# Altium schematic sheet Sheet2.SchDoc
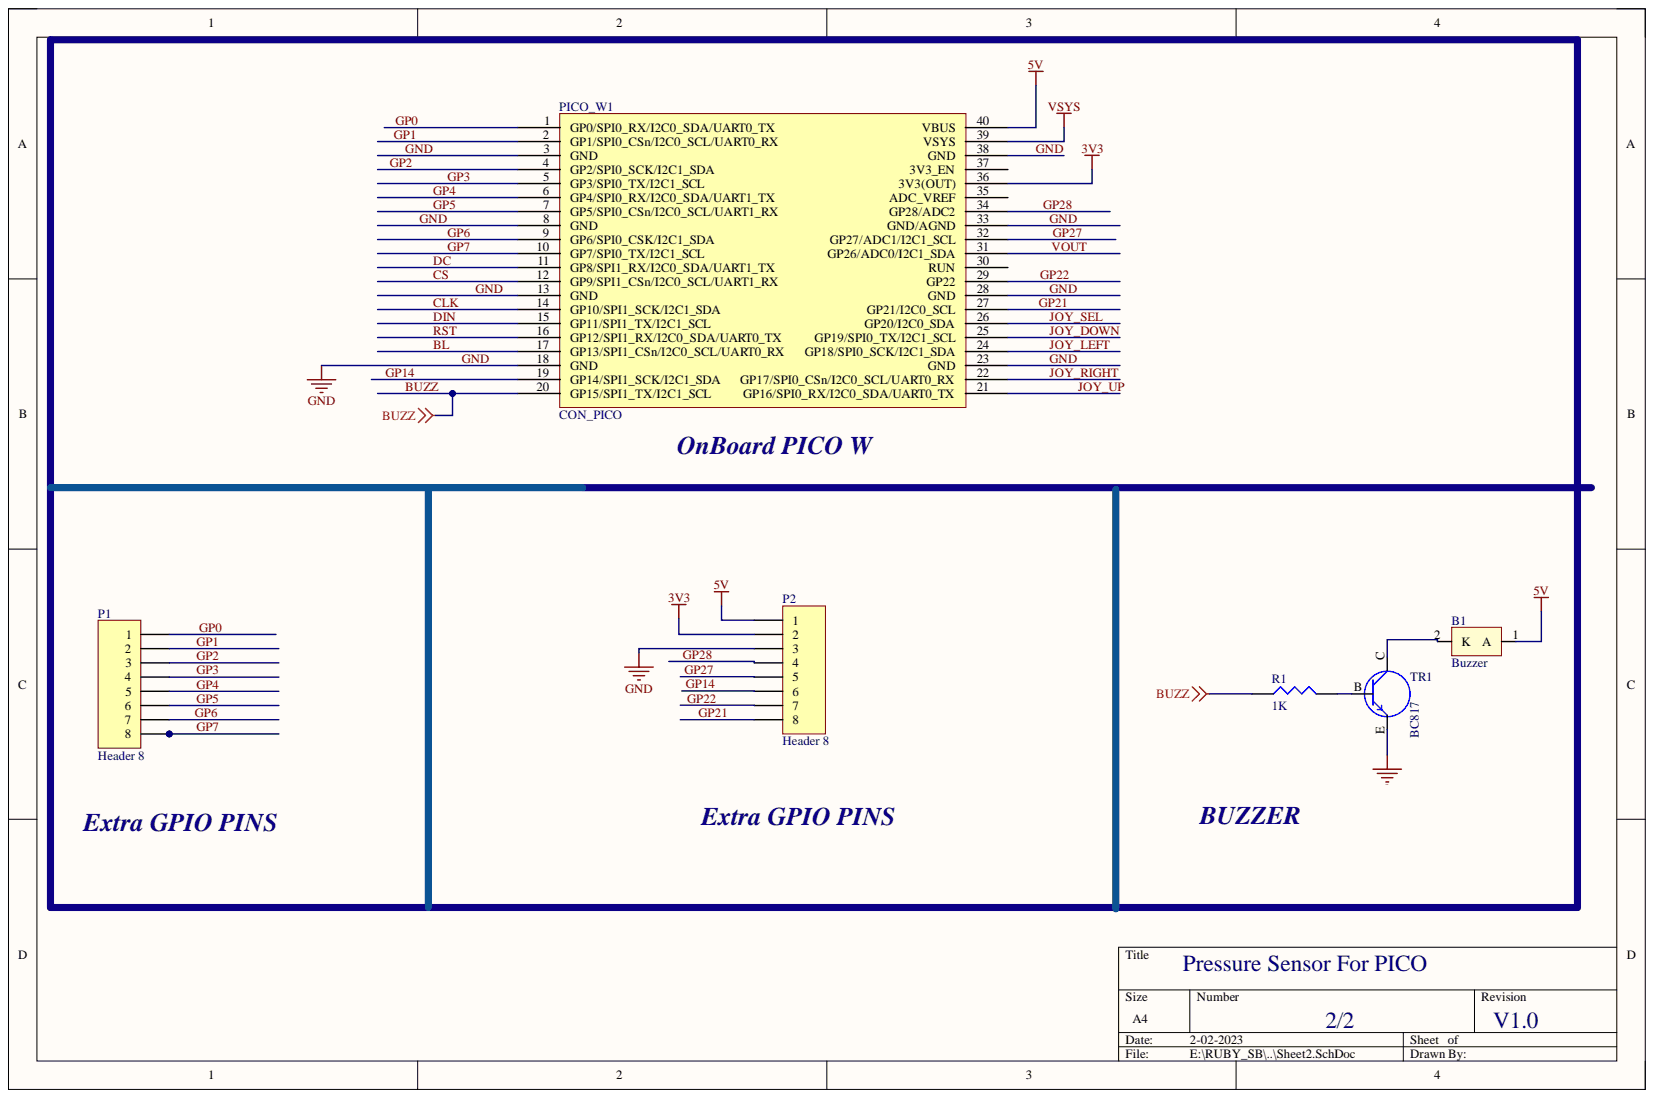
[diagram p0: Sheet2.SchDoc]

## Parts
B1  Buzzer  [Buzzer]
P1  Header 8  [8P_BERG_2.54MM]
P2  Header 8  [8P_BERG_2.54MM]
PICO_W1  CON_PICO  [PICO W]
R1  1K  [R_0603]
TR1  BC817  [SOT23]

## Nets
3V3: P2.2 PICO_W1.36(3V3(OUT))
5V: B1.1 P2.1 PICO_W1.40(VBUS)
VSYS: PICO_W1.39(VSYS)
BL: PICO_W1.17(GP13/SPI1_CSn/I2C0_SCL/UART0_RX)
BUZZ: PICO_W1.20(GP15/SPI1_TX/I2C1_SCL) R1.1
CLK: PICO_W1.14(GP10/SPI1_SCK/I2C1_SDA)
CS: PICO_W1.12(GP9/SPI1_CSn/I2C0_SCL/UART1_RX)
DC: PICO_W1.11(GP8/SPI1_RX/I2C0_SDA/UART1_TX)
DIN: PICO_W1.15(GP11/SPI1_TX/I2C1_SCL)
GND: P2.3 PICO_W1.3(GND) PICO_W1.8(GND) PICO_W1.13(GND) PICO_W1.18(GND) PICO_W1.23(GND) PICO_W1.28(GND) PICO_W1.33(GND/AGND) PICO_W1.38(GND) TR1.E
GP0: P1.1 PICO_W1.1(GP0/SPI0_RX/I2C0_SDA/UART0_TX)
GP1: P1.2 PICO_W1.2(GP1/SPI0_CSn/I2C0_SCL/UART0_RX)
GP2: P1.3 PICO_W1.4(GP2/SPI0_SCK/I2C1_SDA)
GP3: P1.4 PICO_W1.5(GP3/SPI0_TX/I2C1_SCL)
GP4: P1.5 PICO_W1.6(GP4/SPI0_RX/I2C0_SDA/UART1_TX)
GP5: P1.6 PICO_W1.7(GP5/SPI0_CSn/I2C0_SCL/UART1_RX)
GP6: P1.7 PICO_W1.9(GP6/SPI0_CSK/I2C1_SDA)
GP7: P1.8 PICO_W1.10(GP7/SPI0_TX/I2C1_SCL)
GP14: P2.6 PICO_W1.19(GP14/SPI1_SCK/I2C1_SDA)
GP21: P2.8 PICO_W1.27(GP21/I2C0_SCL)
GP22: P2.7 PICO_W1.29(GP22)
GP27: P2.5 PICO_W1.32(GP27/ADC1/I2C1_SCL)
GP28: P2.4 PICO_W1.34(GP28/ADC2)
JOY_DOWN: PICO_W1.25(GP19/SPI0_TX/I2C1_SCL)
JOY_LEFT: PICO_W1.24(GP18/SPI0_SCK/I2C1_SDA)
JOY_RIGHT: PICO_W1.22(GP17/SPI0_CSn/I2C0_SCL/UART0_RX)
JOY_SEL: PICO_W1.26(GP20/I2C0_SDA)
JOY_UP: PICO_W1.21(GP16/SPI0_RX/I2C0_SDA/UART0_TX)
RST: PICO_W1.16(GP12/SPI1_RX/I2C0_SDA/UART0_TX)
VOUT: PICO_W1.31(GP26/ADC0/I2C1_SDA)
NetB1_2: B1.2 TR1.C
NetR1_2: R1.2 TR1.B

Not connected: PICO_W1 30(RUN) 35(ADC_VREF) 37(3V3_EN)

## Library data of the parts
P1 P2 = Header 8: Header, 8-Pin; Component Kind: Standard; LatestRevisionDate: 17-Jul-2002; LatestRevisionNote: Re-released for DXP Platform.; Library Name: Miscellaneous Connectors.IntLib; Signal Integrity: Connector; Publisher: Altium Limited
PICO_W1 = CON40_PICO: Component Kind: Standard; Library Name: dxp_pasl_lib.IntLib
R1 = RES: Component Kind: Standard; Library Name: dxp_pasl_lib.IntLib
TR1 = TRANSISTER_NPN: Component Kind: Standard; Library Name: dxp_pasl_lib.IntLib
B1 = Buzzer: Component Kind: Standard; Library Name: dxp_pasl_lib.IntLib
